# Altium schematic sheet RF_Front-End2.SchDoc
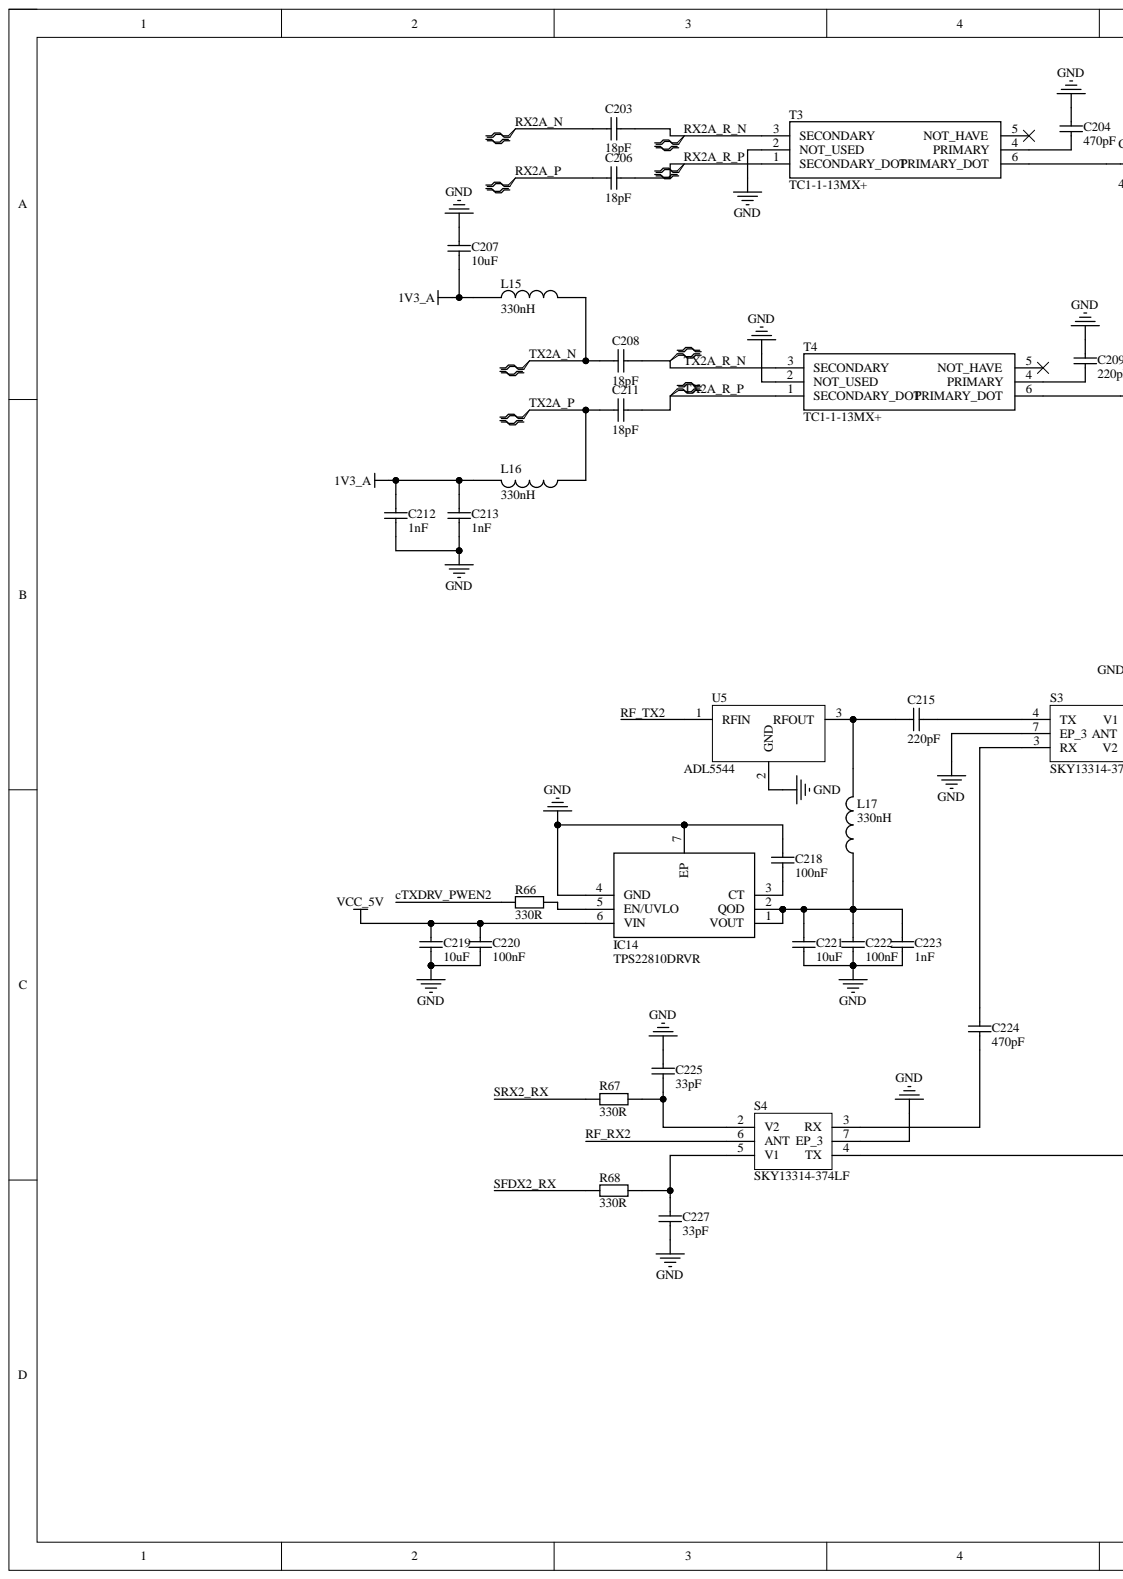
[diagram p0: RF_Front-End2.SchDoc, left]
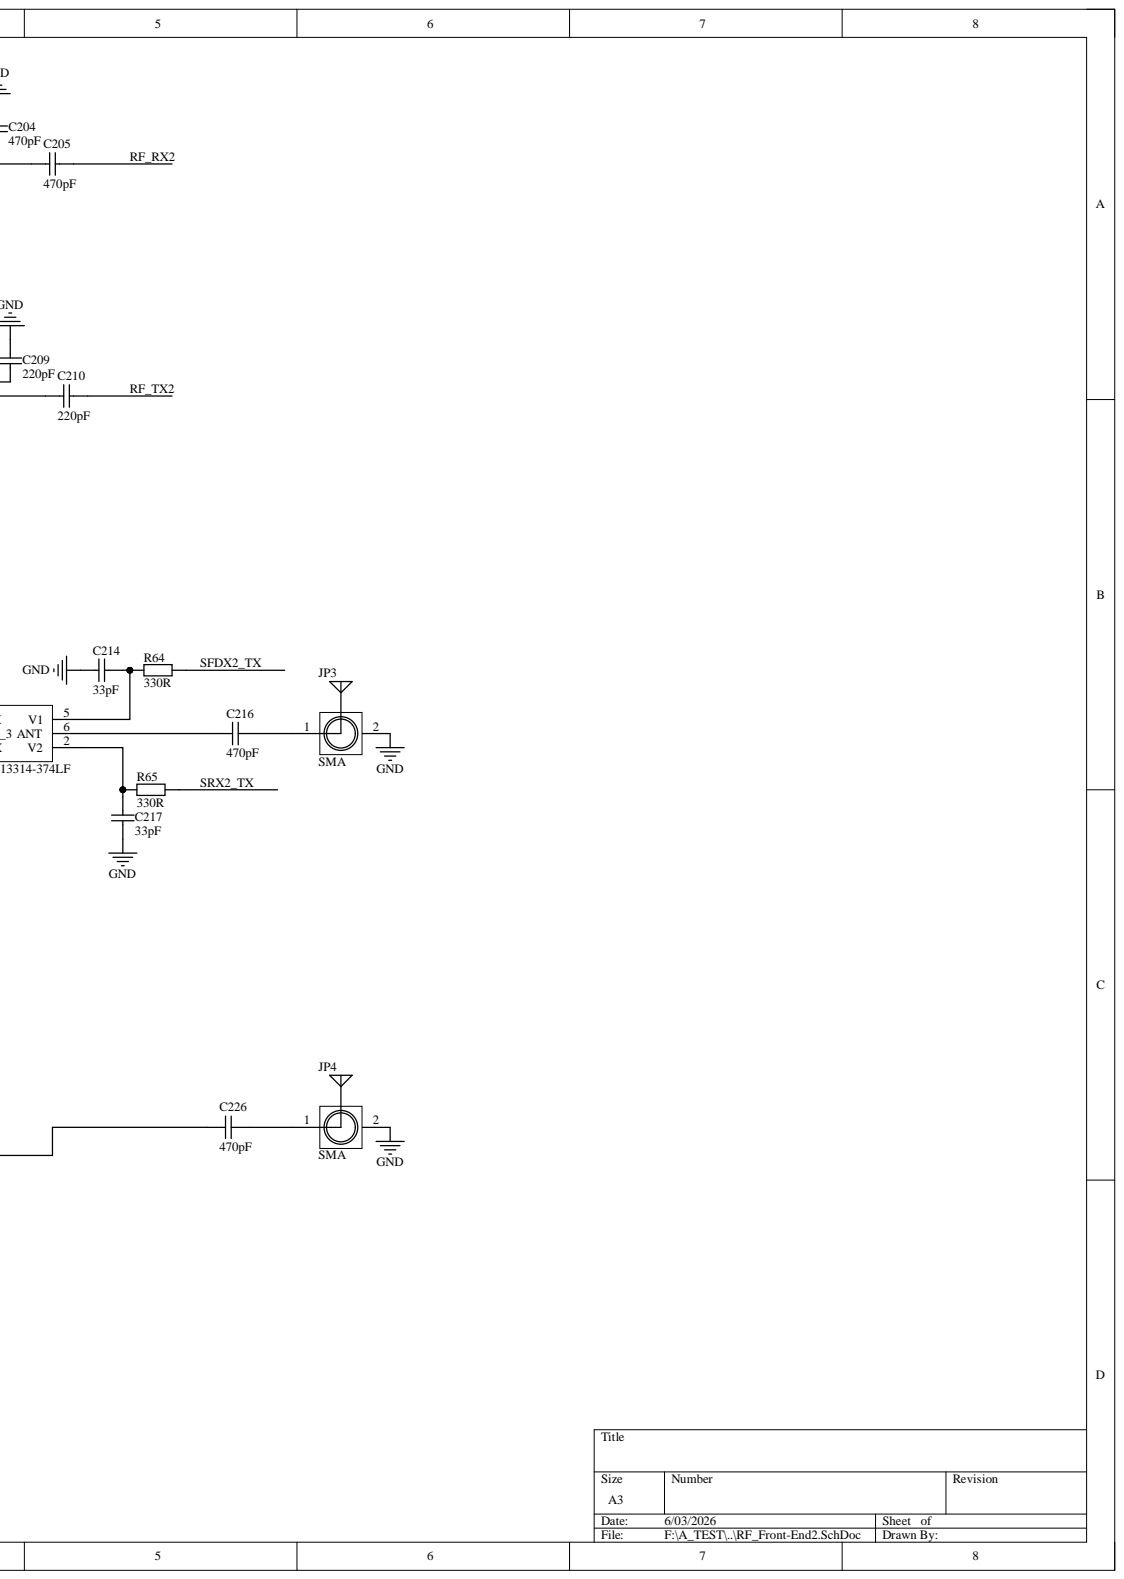
[diagram p1: RF_Front-End2.SchDoc, right]

## Parts
C203  18pF  [C 0402_L]
C204  470pF  [C 0402_L]
C205  470pF  [C 0402_L]
C206  18pF  [C 0402_L]
C207  10uF  [C 0402_L]
C208  18pF  [C 0402_L]
C209  220pF  [C 0402_L]
C210  220pF  [C 0402_L]
C211  18pF  [C 0402_L]
C212  1nF  [C 0402_L]
C213  1nF  [C 0402_L]
C214  33pF  [C 0402_L]
C215  220pF  [C 0402_L]
C216  470pF  [C 0402_L]
C217  33pF  [C 0402_L]
C218  100nF  [C 0402_L]
C219  10uF  [C 0603_L]
C220  100nF  [C 0402_L]
C221  10uF  [C 0603_L]
C222  100nF  [C 0402_L]
C223  1nF  [C 0402_L]
C224  470pF  [C 0402_L]
C225  33pF  [C 0402_L]
C226  470pF  [C 0402_L]
C227  33pF  [C 0402_L]
IC14  TPS22810DRVR  [SON65P200X200X80-7N]
JP3  SMA  [SMA-KE - 0.5mm]
JP4  SMA  [SMA-KE - 0.5mm]
L15  330nH  [L 0402]
L16  330nH  [L 0402]
L17  330nH  [L 0402]
R64  330R  [R 0402_L]
R65  330R  [R 0402_L]
R66  330R  [R 0402_L]
R67  330R  [R 0402_L]
R68  330R  [R 0402_L]
S3  SKY13314-374LF  [SKY13314374LF]
S4  SKY13314-374LF  [SKY13314374LF]
T3  TC1-1-13MX+  [TC414]
T4  TC1-1-13MX+  [TC414]
U5  ADL5544  [SOT89]

## Nets
1V3_A: C207.1 C212.1 C213.1 L15.1 L16.2
GND: C204.1 C207.2 C209.1 C212.2 C213.2 C214.2 C217.2 C218.2 C219.1 C220.1 C221.1 C222.1 C223.1 C225.2 C227.2 IC14.4(GND) IC14.7(EP) JP3.2 JP4.2 S3.7(EP_3) S4.7(EP_3) T3.2(NOT_USED) T4.2(NOT_USED) U5.2
VCC_5V: C219.2 C220.2 IC14.6(VIN)
cTXDRV_PWEN2: R66.1
RF_RX2: C205.2 S4.6(ANT)
RF_TX2: C210.2 U5.1
RX2A_N: C203.1
RX2A_P: C206.1
RX2A_R_N: C203.2 T3.3(SECONDARY)
RX2A_R_P: C206.2 T3.1(SECONDARY_DOT)
SFDX2_RX: R68.1
SFDX2_TX: R64.2
SRX2_RX: R67.1
SRX2_TX: R65.2
TX2A_N: C208.1 L15.2
TX2A_P: C211.1 L16.1
TX2A_R_N: C208.2 T4.3(SECONDARY)
TX2A_R_P: C211.2 T4.1(SECONDARY_DOT)
NetC204_2: C204.2 T3.4(PRIMARY)
NetC205_1: C205.1 T3.6(PRIMARY_DOT)
NetC209_2: C209.2 T4.4(PRIMARY)
NetC210_1: C210.1 T4.6(PRIMARY_DOT)
NetC214_1: C214.1 R64.1 S3.5(V1)
NetC215_1: C215.1 S3.4(TX)
NetC215_2: C215.2 L17.2 U5.3
NetC216_1: C216.1 JP3.1
NetC216_2: C216.2 S3.6(ANT)
NetC217_1: C217.1 R65.1 S3.2(V2)
NetC218_1: C218.1 IC14.3(CT)
NetC221_2: C221.2 C222.2 C223.2 IC14.1(VOUT) IC14.2(QOD) L17.1
NetC224_1: C224.1 S3.3(RX)
NetC224_2: C224.2 S4.3(RX)
NetC225_1: C225.1 R67.2 S4.2(V2)
NetC226_1: C226.1 JP4.1
NetC226_2: C226.2 S4.4(TX)
NetC227_1: C227.1 R68.2 S4.5(V1)
NetIC14_5: IC14.5(EN/UVLO) R66.2

Not connected: T3 5(NOT_HAVE); T4 5(NOT_HAVE)

## Library data of the parts
C203-C227 = Cap: 贴片电容; Component Kind: Standard; Library Name: A_Schlib.SchLib
  C203 C206 C208 C211: value: 18pF
  C204 C205 C216 C224 C226: value: 470pF
  C207 C219 C221: value: 10uF
  C209 C210 C215: value: 220pF
  C212 C213 C223: value: 1nF
  C214 C217 C225 C227: value: 33pF
  C218 C220 C222: value: 100nF
IC14 = TPS22810DRVR: Integrated Circuit; Manufacturer_Name: Texas Instruments; Arrow Part Number: TPS22810DRVR; Arrow Price/Stock: https://www.arrow.com/en/products/tps22810drvr/texas-instruments?region=nac; Component Kind: Standard; Datasheet Link: http://www.ti.com/lit/gpn/tps22810; Height: 0.8mm; Library Name: SamacSys.SchLib; Manufacturer_Part_Number: TPS22810DRVR; Mouser Part Number: 595-TPS22810DRVR; Mouser Price/Stock: https://www.mouser.co.uk/ProductDetail/Texas-Instruments/TPS22810DRVR?qs=5aG0NVq1C4wkfnc1%252B6LezA%3D%3D
JP3 JP4 = SMA: SMA-2.4G射频天线接口; 版权归属: 源创客; BOM名称: SMA-2.4G射频天线接口; Component Kind: Standard; Library Name: A_Schlib.SchLib; 学习资源: www.ooocke.com
L15-L17 = 电感: 包含功率; Component Kind: Standard; Library Name: A_Schlib.SchLib
R64-R68 = Res: 通用; Component Kind: Standard; Library Name: A_Schlib.SchLib; value: 330R
S3 S4 = SKY13314-374LF: Switch; Manufacturer_Name: Skyworks; Component Kind: Standard; Datasheet Link: https://www.skyworksinc.com/-/media/SkyWorks/Documents/Products/101-200/SKY13314_374LF_200882J.pdf; Height: 0.5mm; Library Name: SamacSys.SchLib; Manufacturer_Part_Number: SKY13314-374LF; Mouser Part Number: 873-SKY13314-374LF; Mouser Price/Stock: https://www.mouser.co.uk/ProductDetail/Skyworks-Solutions-Inc/SKY13314-374LF?qs=WMHGlxXAKT%252BJLauIraDVsw%3D%3D
T3 T4 = TC1-1-13M+: Transformer; Manufacturer_Name: Mini-Circuits; Component Kind: Standard; Datasheet Link: https://datasheet.datasheetarchive.com/originals/distributors/Datasheets-28/DSA-546322.pdf; Height: 4.06mm; Library Name: SamacSys.SchLib; Manufacturer_Part_Number: TC1-1-13M+; Mouser Part Number: 139-TC1-1-13M; Mouser Price/Stock: https://www.mouser.co.uk/ProductDetail/Mini-Circuits/TC1-1-13M%2b?qs=xZ%2FP%252Ba9zWqYek%252BZGAF2fWg%3D%3D
U5 = PGA-102+: Component Kind: Standard; Library Name: A_Schlib.SchLib
